# Altium schematic sheet biv2-LRTP_1a.SchDoc
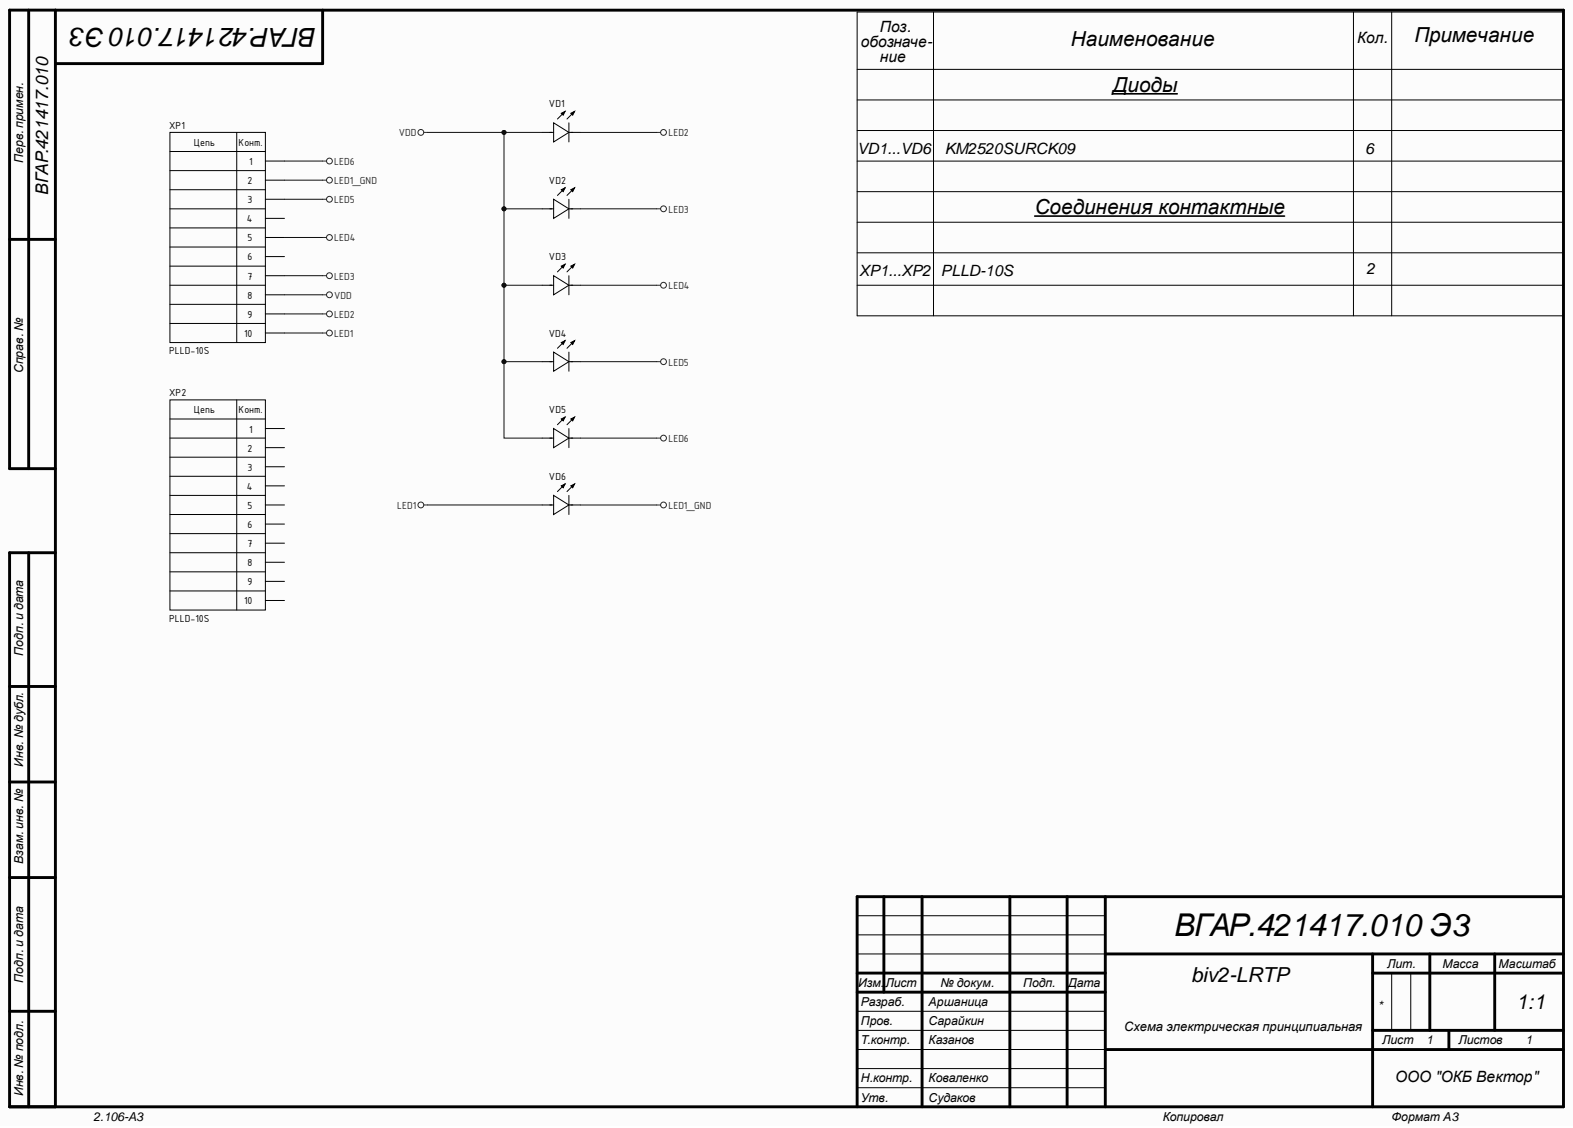
[diagram p0: biv2-LRTP_1a.SchDoc]

## Parts
VD1  KM2520SURCK09  [KM2520SURCK09]
VD2  KM2520SURCK09  [KM2520SURCK09]
VD3  KM2520SURCK09  [KM2520SURCK09]
VD4  KM2520SURCK09  [KM2520SURCK09]
VD5  KM2520SURCK09  [KM2520SURCK09]
VD6  KM2520SURCK09  [KM2520SURCK09]
XP1  PLLD-10S  [CON: PLLD-10S]
XP2  PLLD-10S  [CON: PLLD-10S]

## Nets
LED1: VD6.1 XP1.10
LED1_GND: VD6.2 XP1.2
LED2: VD1.2 XP1.9
LED3: VD2.2 XP1.7
LED4: VD3.2 XP1.5
LED5: VD4.2 XP1.3
LED6: VD5.2 XP1.1
VDD: VD1.1 VD2.1 VD3.1 VD4.1 VD5.1 XP1.8

Not connected: XP1 4 6; XP2 1 2 3 4 5 6 7 8 9 10

## Library data of the parts
VD1-VD6 = KM2520SURCK09: LED; Component Kind: Standard; Library Name: Kingbright LED.IntLib
XP1 XP2 = PLLD-10S: Step 1.27; Component Kind: Standard; Library Name: connector.IntLib
